# Altium schematic sheet Bottom_REC_C.SchDoc
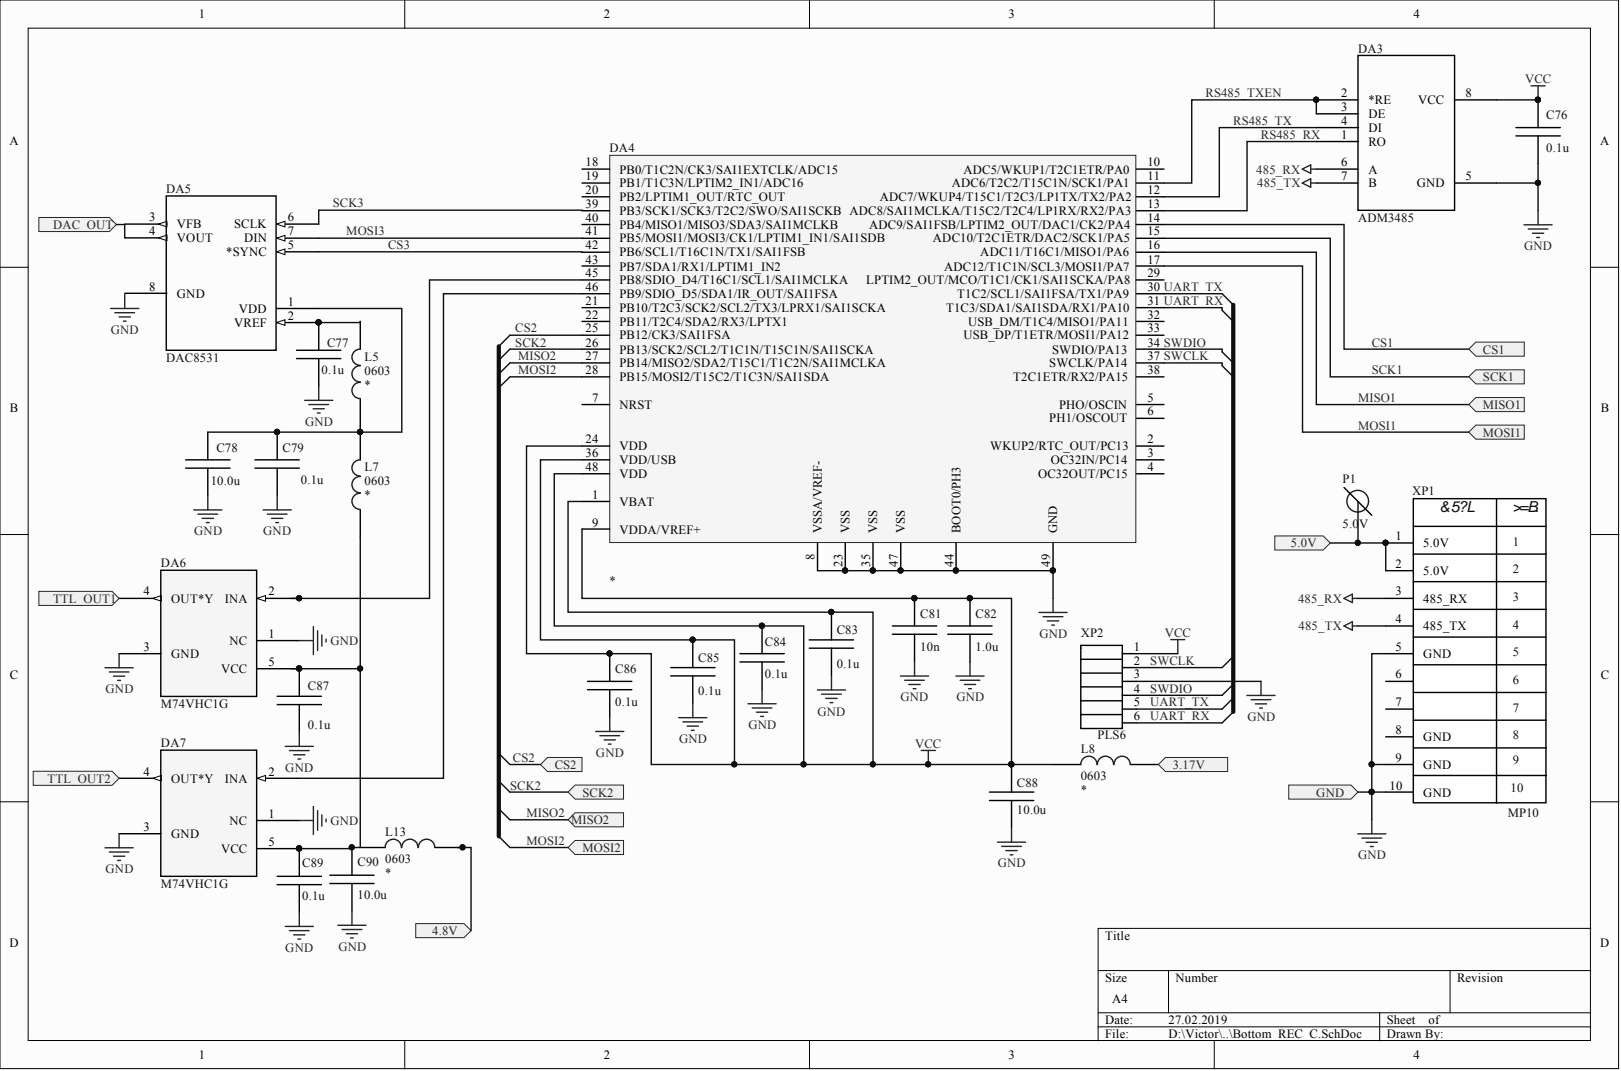
[diagram p0: Bottom_REC_C.SchDoc]

## Parts
C76  Capacitance: 0.1u  [0402]  (hidden comment: Capacitor)
C77  Capacitance: 0.1u  [0402]  (hidden comment: Capacitor)
C78  Capacitance: 10.0u  [0603]  (hidden comment: Capacitor)
C79  Capacitance: 0.1u  [0402]  (hidden comment: Capacitor)
C81  Capacitor  [0402]  (value not drawn)
C82  Capacitance: 1.0u  [0402]  (hidden comment: Capacitor)
C83  Capacitance: 0.1u  [0402]  (hidden comment: Capacitor)
C84  Capacitance: 0.1u  [0402]  (hidden comment: Capacitor)
C85  Capacitance: 0.1u  [0402]  (hidden comment: Capacitor)
C86  Capacitance: 0.1u  [0402]  (hidden comment: Capacitor)
C87  Capacitance: 0.1u  [0402]  (hidden comment: Capacitor)
C88  Capacitance: 10.0u  [0603]  (hidden comment: Capacitor)
C89  Capacitance: 0.1u  [0402]  (hidden comment: Capacitor)
C90  Capacitance: 10.0u  [0402]  (hidden comment: Capacitor)
DA3  ADM3485  [ADM3485]
DA4  STM32L433CCT  [STM32L433CCT]  (value not drawn)
DA5  Capacitor  [DAC8531]  (value not drawn)
DA6  (no value)  [M74VHC1GT125D]
DA7  (no value)  [M74VHC1GT125D]
L5  Inductor  [0603]  (value not drawn)
L7  Inductor  [0603]  (value not drawn)
L8  Inductor  [0603]  (value not drawn)
L13  Inductor  [0603]  (value not drawn)
P1  Capacitance: 5.0V  [PAD]  (hidden comment: Capacitor)
XP1  Capacitance: МР10  [Connector_10pin]  (hidden comment: Capacitor)
XP2  Capacitor  [PLS6]  (value not drawn)

## Ports
3.17V, 4.8V, 5.0V, CS1, CS2, DAC_OUT, GND, MISO1, MISO2, MOSI1, MOSI2, SCK1, SCK2, TTL_OUT1, TTL_OUT2

## Nets
485_RX: DA3.6(A) XP1.3(485_RX)
485_TX: DA3.7(B) XP1.4(485_TX)
VCC: C76.2 C81.2 C82.2 C83.2 C84.2 C85.2 C86.2 C88.2 DA3.8(VCC) DA4.1(VBAT) DA4.9(VDDA/VREF+) DA4.24(VDD) DA4.36(VDD/USB) DA4.48(VDD) L8.1 XP2.1
3.17V: L8.2 ; port 3.17V
4.8V: L13.2 ; port 4.8V
5.0V: XP1.1(5.0V) XP1.2(5.0V) ; port 5.0V
CS1: DA4.14(ADC9/SAI1FSB/LPTIM2_OUT/DAC1/CK2/PA4) ; port CS1
CS2: DA4.25(PB12/CK3/SAI1FSA) ; port CS2
CS3: DA4.42(PB6/SCL1/T16C1N/TX1/SAI1FSB) DA5.5(*SYNC)
DAC_OUT: DA5.4(VOUT) ; port DAC_OUT
GND: C76.1 C77.1 C78.1 C79.1 C81.1 C82.1 C83.1 C84.1 C85.1 C86.1 C87.1 C88.1 C89.1 C90.1 DA3.5(GND) DA4.8(VSSA/VREF-) DA4.23(VSS) DA4.35(VSS) DA4.44(BOOT0/PH3) DA4.47(VSS) DA4.49(GND) DA6.1(NC) DA6.3(GND) DA7.1(NC) DA7.3(GND) XP1.5(GND) XP1.9(GND) XP1.10(GND) XP2.3 ; port GND
MISO1: DA4.16(ADC11/T16C1/MISO1/PA6) ; port MISO1
MISO2: DA4.27(PB14/MISO2/SDA2/T15C1/T1C2N/SAI1MCLKA) ; port MISO2
MOSI1: DA4.17(ADC12/T1C1N/SCL3/MOSI1/PA7) ; port MOSI1
MOSI2: DA4.28(PB15/MOSI2/T15C2/T1C3N/SAI1SDA) ; port MOSI2
MOSI3: DA4.41(PB5/MOSI1/MOSI3/CK1/LPTIM1_IN1/SAI1SDB) DA5.7(DIN)
RS485_RX: DA3.1(RO) DA4.13(ADC8/SAI1MCLKA/T15C2/T2C4/LP1RX/RX2/PA3)
RS485_TX: DA3.4(DI) DA4.12(ADC7/WKUP4/T15C1/T2C3/LP1TX/TX2/PA2)
RS485_TXEN: DA3.2(*RE) DA3.3(DE) DA4.11(ADC6/T2C2/T15C1N/SCK1/PA1)
SCK1: DA4.15(ADC10/T2C1ETR/DAC2/SCK1/PA5) ; port SCK1
SCK2: DA4.26(PB13/SCK2/SCL2/T1C1N/T15C1N/SAI1SCKA) ; port SCK2
SCK3: DA4.39(PB3/SCK1/SCK3/T2C2/SWO/SAI1SCKB) DA5.6(SCLK)
SWCLK: DA4.37(SWCLK/PA14) XP2.2
SWDIO: DA4.34(SWDIO/PA13) XP2.4
TTL_OUT1: DA6.4(OUT*Y) ; port TTL_OUT1
TTL_OUT2: DA7.4(OUT*Y) ; port TTL_OUT2
UART_RX: DA4.31(T1C3/SDA1/SAI1SDA/RX1/PA10) XP2.6
UART_TX: DA4.30(T1C2/SCL1/SAI1FSA/TX1/PA9) XP2.5
NetC77_2: C77.2 DA5.2(VREF) L5.2
NetC78_2: C78.2 C79.2 DA5.1(VDD) L5.1 L7.2
NetC87_2: C87.2 DA6.5(VCC) L7.1
NetC89_2: C89.2 C90.2 DA7.5(VCC) L13.1
NetDA4_45: DA4.45(PB8/SDIO_D4/T16C1/SCL1/SAI1MCLKA) DA6.2(INA)
NetDA4_46: DA4.46(PB9/SDIO_D5/SDA1/IR_OUT/SAI1FSA) DA7.2(INA)
N00087:
N00088:

Not connected: DA4 2(WKUP2/RTC_OUT/PC13) 3(OC32IN/PC14) 4(OC32OUT/PC15) 5(PHO/OSCIN) 6(PH1/OSCOUT) 7(NRST) 10(ADC5/WKUP1/T2C1ETR/PA0) 18(PB0/T1C2N/CK3/SAI1EXTCLK/ADC15) 19(PB1/T1C3N/LPTIM2_IN1/ADC16) 20(PB2/LPTIM1_OUT/RTC_OUT) 21(PB10/T2C3/SCK2/SCL2/TX3/LPRX1/SAI1SCKA) 22(PB11/T2C4/SDA2/RX3/LPTX1) 29(LPTIM2_OUT/MCO/T1C1/CK1/SAI1SCKA/PA8) 32(USB_DM/T1C4/MISO1/PA11) 33(USB_DP/T1ETR/MOSI1/PA12) 38(T2C1ETR/RX2/PA15) 40(PB4/MISO1/MISO3/SDA3/SAI1MCLKB) 43(PB7/SDA1/RX1/LPTIM1_IN2); DA5 3(VFB) 8(GND); XP1 6 7 8(GND)

## Library data of the parts
DA5 = DAC8531: Capacitance: DAC8531; Component Kind: Standard; Library Name: Receiver_C.IntLib
DA6 DA7 = M74VHC1G: Capacitance: M74VHC1G; Component Kind: Standard; Library Name: Receiver_C.IntLib
L5 L7 = Inductor: TL160808U601; Component Kind: Standard; Library Name: Receiver_C.IntLib; Type: 0603
P1 = Pad: Component Kind: Standard; Library Name: Receiver_C.IntLib
XP1 = Connector_10pin: Component Kind: Standard; Library Name: Receiver_C.IntLib
XP2 = PLS6: : PLS6; Component Kind: Standard; Library Name: Receiver_C.IntLib
C76-C79 C81-C90 = Capacitor: Component Kind: Standard; Library Name: Receiver_C.IntLib
  C81: : 10n
DA3 = ADM3485: Capacitance: ADM3485; Component Kind: Standard; Library Name: Receiver_C.IntLib
DA4 = STM32L433CCT: Component Kind: Standard; Library Name: Receiver_C.IntLib
L8 L13 = Inductor: Component Kind: Standard; Library Name: Receiver_C.IntLib; Type: 0603
